# Altium schematic sheet BANK_POWER.SchDoc
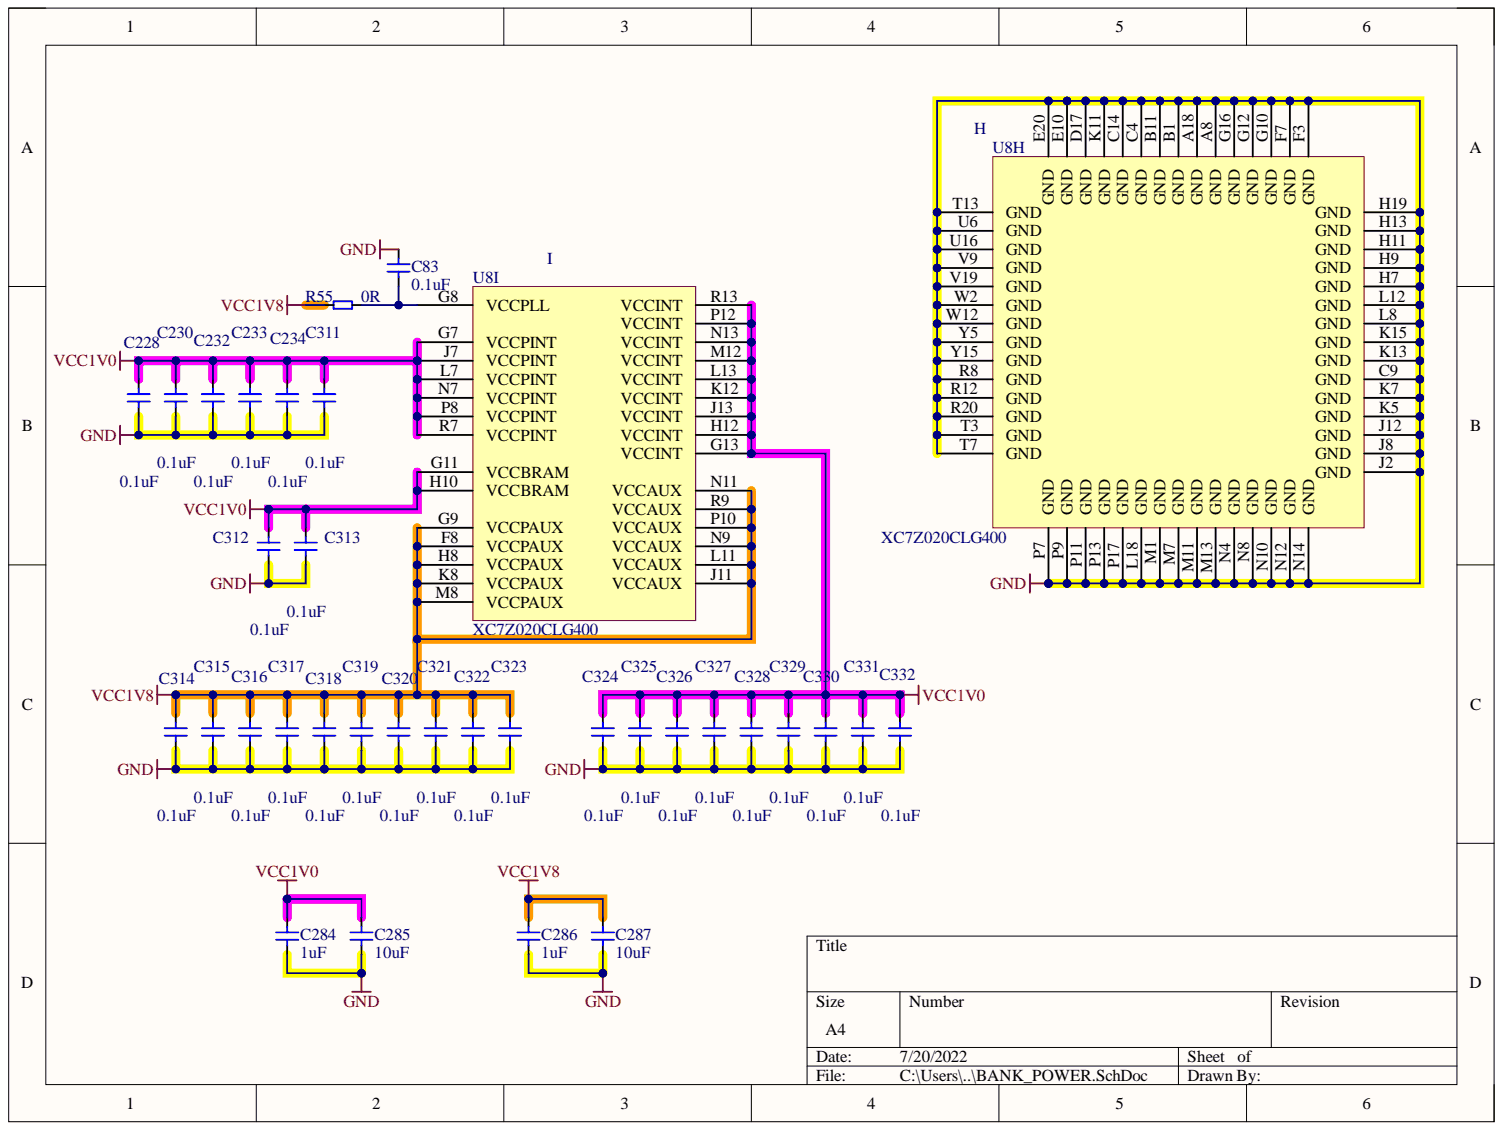
[diagram p0: BANK_POWER.SchDoc]

## Parts
C83  0.1uF  [C0201]
C228  0.1uF  [C0201]
C230  0.1uF  [C0201]
C232  0.1uF  [C0201]
C233  0.1uF  [C0201]
C234  0.1uF  [C0201]  (value not drawn)
C284  1uF  [C0402]
C285  10uF  [C0603]
C286  1uF  [C0402]
C287  10uF  [C0603]
C311  0.1uF  [C0201]
C312  0.1uF  [C0201]
C313  0.1uF  [C0201]
C314  0.1uF  [C0201]
C315  0.1uF  [C0201]
C316  0.1uF  [C0201]
C317  0.1uF  [C0201]
C318  0.1uF  [C0201]
C319  0.1uF  [C0201]
C320  0.1uF  [C0201]
C321  0.1uF  [C0201]
C322  0.1uF  [C0201]
C323  0.1uF  [C0201]
C324  0.1uF  [C0201]
C325  0.1uF  [C0201]
C326  0.1uF  [C0201]
C327  0.1uF  [C0201]
C328  0.1uF  [C0201]
C329  0.1uF  [C0201]
C330  0.1uF  [C0201]
C331  0.1uF  [C0201]
C332  0.1uF  [C0201]
R55  0R  [0402]
U8  XC7Z020CLG400  [MY_CLG400]  (parts H,I)

## Nets
GND: C83.1 C228.2 C230.2 C232.2 C233.2 C234.2 C284.1 C285.1 C286.1 C287.1 C311.2 C312.2 C313.2 C314.2 C315.2 C316.2 C317.2 C318.2 C319.2 C320.2 C321.2 C322.2 C323.2 C324.2 C325.2 C326.2 C327.2 C328.2 C329.2 C330.2 C331.2 C332.2 U8.A8(GND) U8.A18(GND) U8.B1(GND) U8.B11(GND) U8.C4(GND) U8.C9(GND) U8.C14(GND) U8.D17(GND) U8.E10(GND) U8.E20(GND) U8.F3(GND) U8.F7(GND) U8.G10(GND) U8.G12(GND) U8.G16(GND) U8.H7(GND) U8.H9(GND) U8.H11(GND) U8.H13(GND) U8.H19(GND) U8.J2(GND) U8.J8(GND) U8.J12(GND) U8.K5(GND) U8.K7(GND) U8.K11(GND) U8.K13(GND) U8.K15(GND) U8.L8(GND) U8.L12(GND) U8.L18(GND) U8.M1(GND) U8.M7(GND) U8.M11(GND) U8.M13(GND) U8.N4(GND) U8.N8(GND) U8.N10(GND) U8.N12(GND) U8.N14(GND) U8.P7(GND) U8.P9(GND) U8.P11(GND) U8.P13(GND) U8.P17(GND) U8.R8(GND) U8.R12(GND) U8.R20(GND) U8.T3(GND) U8.T7(GND) U8.T13(GND) U8.U6(GND) U8.U16(GND) U8.V9(GND) U8.V19(GND) U8.W2(GND) U8.W12(GND) U8.Y5(GND) U8.Y15(GND)
VCC1V0: C228.1 C230.1 C232.1 C233.1 C234.1 C284.2 C285.2 C311.1 C312.1 C313.1 C324.1 C325.1 C326.1 C327.1 C328.1 C329.1 C330.1 C331.1 C332.1 U8.G7(VCCPINT) U8.G11(VCCBRAM) U8.G13(VCCINT) U8.H10(VCCBRAM) U8.H12(VCCINT) U8.J7(VCCPINT) U8.J13(VCCINT) U8.K12(VCCINT) U8.L7(VCCPINT) U8.L13(VCCINT) U8.M12(VCCINT) U8.N7(VCCPINT) U8.N13(VCCINT) U8.P8(VCCPINT) U8.P12(VCCINT) U8.R7(VCCPINT) U8.R13(VCCINT)
VCC1V8: C286.2 C287.2 C314.1 C315.1 C316.1 C317.1 C318.1 C319.1 C320.1 C321.1 C322.1 C323.1 R55.1 U8.F8(VCCPAUX) U8.G9(VCCPAUX) U8.H8(VCCPAUX) U8.J11(VCCAUX) U8.K8(VCCPAUX) U8.L11(VCCAUX) U8.M8(VCCPAUX) U8.N9(VCCAUX) U8.N11(VCCAUX) U8.P10(VCCAUX) U8.R9(VCCAUX)
NetC83_2: C83.2 R55.2 U8.G8(VCCPLL)

## Library data of the parts
C83 C228 C230 C232-C234 C284-C287 C311-C332 = C: Capacitor; Component Kind: Standard; Library Name: 电容-SCH.LIB
R55 = RES2: Component Kind: Standard; Library Name: 电阻-SCH.LIB
U8 = XC7Z020CLG400: Component Kind: Standard; Library Name: Schlib1.SchLib
